# Altium schematic sheet [7] EEPROM_JTAG.SchDoc("[7] EEPROM_JTAG")
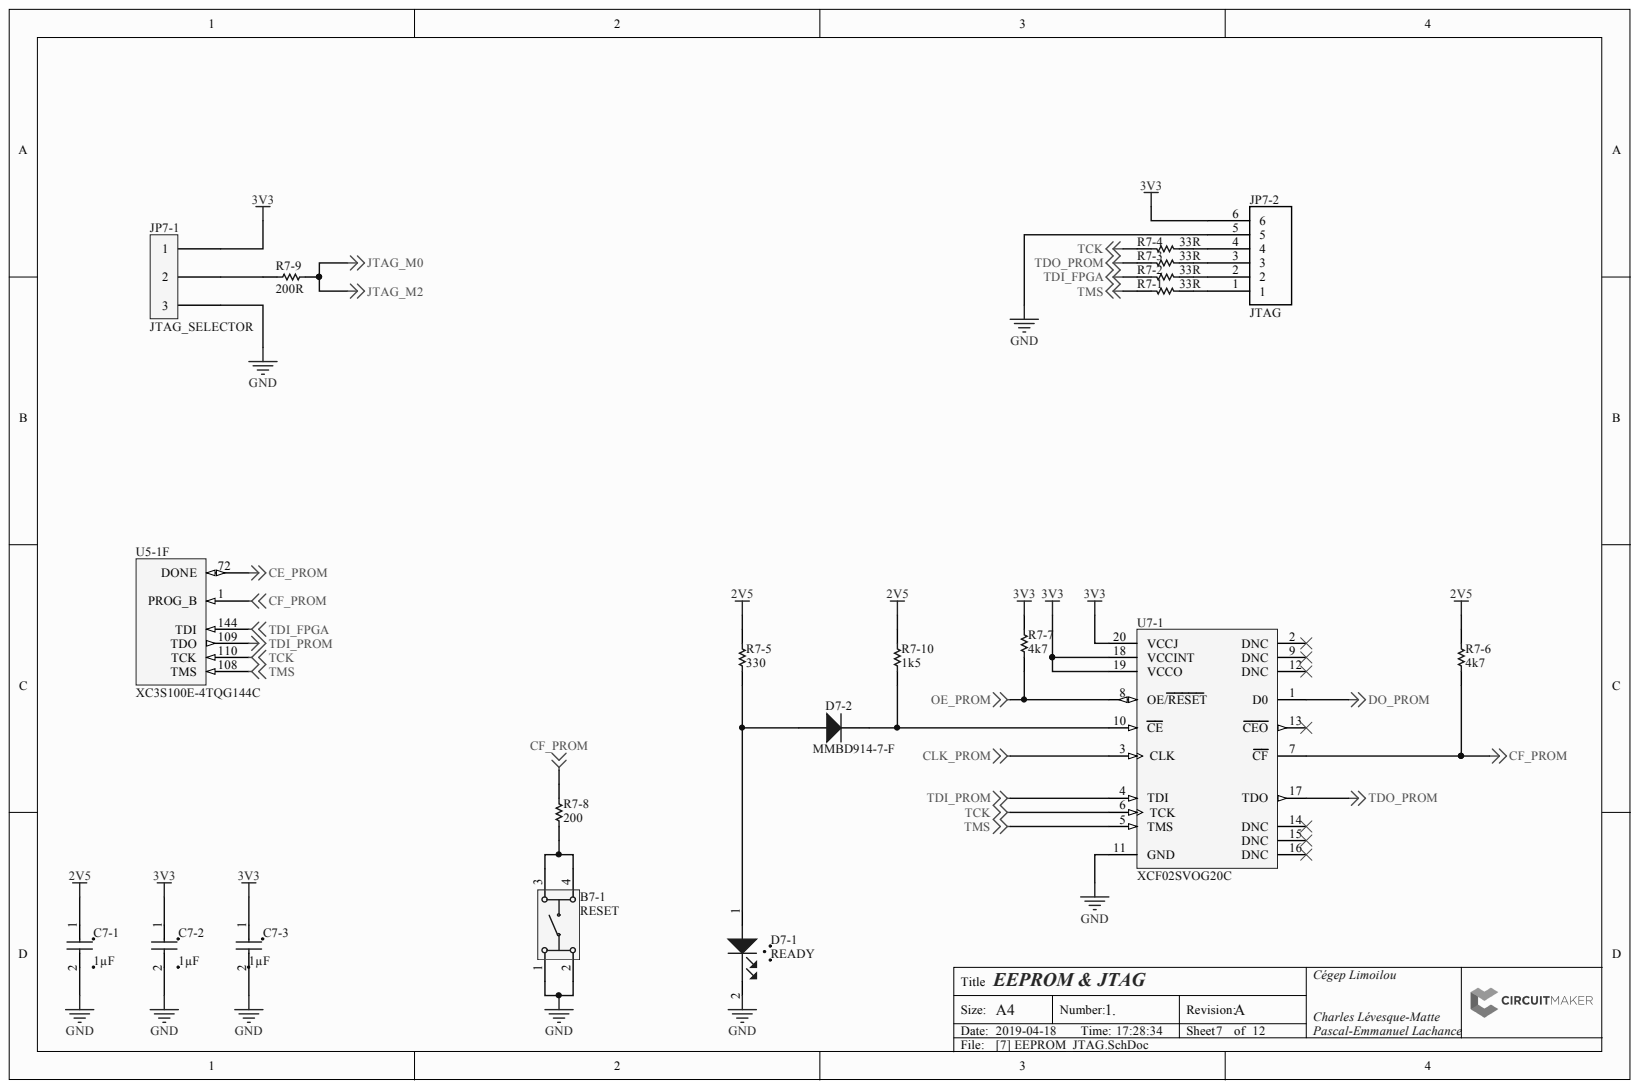
[diagram p0: [7] EEPROM_JTAG.SchDoc]

## Parts
B7-1  RESET  [PCB-gu3nyp8n3znpe43o61p2-1]
C7-1  1µF  [PCB-hxapk9n2qzqgg8bo7kac-1]
C7-2  1µF  [PCB-hxapk9n2qzqgg8bo7kac-1]
C7-3  1µF  [PCB-hxapk9n2qzqgg8bo7kac-1]
D7-1  READY  [PCB-loj9e2phlcze9wuynh4o-1]
D7-2  MMBD914-7-F  [PCB-c6nu3vtdsg4sq5t4bise-1]
JP7-1  JTAG_SELECTOR  [PCB-ugwstohemlnv9dppwl48-1]
JP7-2  JTAG  [PCB-3czfjzl4xee1cb5nxbdw-1]
R7-1  33R  [PCB-9ahxsq8gddjqcnfjmojj-1]
R7-2  33R  [PCB-9ahxsq8gddjqcnfjmojj-1]
R7-3  33R  [PCB-9ahxsq8gddjqcnfjmojj-1]
R7-4  33R  [PCB-9ahxsq8gddjqcnfjmojj-1]
R7-5  330  [RESC1508X06N]
R7-6  4k7  [PCB-g8ucq7vw1s2sdhfr4zem-1]
R7-7  4k7  [PCB-g8ucq7vw1s2sdhfr4zem-1]
R7-8  200  [PCB-u0msi5n9597hlhe0i4x3-1]
R7-9  200R  [PCB-u0msi5n9597hlhe0i4x3-1]
R7-10  1k5  [PCB-9ahxsq8gddjqcnfjmojj-1]
U5-1  XC3S100E-4TQG144C  [TQG144_L]  (part F)
U7-1  XCF02SVOG20C  [VOG20_M]

## Nets
2V5: C7-1.1 R7-5.2 R7-6.2 R7-10.2
3V3: C7-2.1 C7-3.1 JP7-1.1 JP7-2.6 R7-7.2 U7-1.18(VCCINT) U7-1.19(VCCO) U7-1.20(VCCJ)
CE_PROM: U5-1.72(DONE)
CF_PROM: R7-6.1 R7-8.2 U5-1.1(PROG_B) U7-1.7(CF)
CLK_PROM: U7-1.3(CLK)
DO_PROM: U7-1.1(D0)
GND: B7-1.1 B7-1.2 C7-1.2 C7-2.2 C7-3.2 D7-1.2 JP7-1.3 JP7-2.5 U7-1.11(GND)
JTAG_M0: R7-9.2
OE_PROM: R7-7.1 U7-1.8(OE/RESET)
TCK: R7-4.1 U5-1.110(TCK) U7-1.6(TCK)
TDI_FPGA: R7-2.1 U5-1.144(TDI)
TDI_PROM: U5-1.109(TDO) U7-1.4(TDI)
TDO_PROM: R7-3.1 U7-1.17(TDO)
TMS: R7-1.1 U5-1.108(TMS) U7-1.5(TMS)
NetB7-1_3: B7-1.3 B7-1.4 R7-8.1
NetD7-1_1: D7-1.1 D7-2.A R7-5.1
NetD7-2_K: D7-2.K R7-10.1 U7-1.10(CE)
NetJP7-1_2: JP7-1.2 R7-9.1
NetJP7-2_1: JP7-2.1 R7-1.2
NetJP7-2_2: JP7-2.2 R7-2.2
NetJP7-2_3: JP7-2.3 R7-3.2
NetJP7-2_4: JP7-2.4 R7-4.2

Not connected: U7-1 2(DNC) 9(DNC) 12(DNC) 13(CEO) 14(DNC) 15(DNC) 16(DNC)

## Library data of the parts
B7-1 = CMP-1012202-7: Switch Tactile N.O. SPST Round Button Gull Wing 0.05A 12VDC 100000Cycles 1.6N SMD Box; Manufacturer Part Number: 1301.9314; Contacts Type: SPST-NO; Component Kind: Standard; Library Name: FavoritesLibrary; Mounting Style: Surface Mount; OctopartID: 06e1cfb9c64ad926; Octopart URL: https://octopart.com/1301.9314-schurter-5432781; Operating Temperature: 70.0 °C (max); Packaging: Bulk; REACH SVHC Compliance: No SVHC; RoHS: Compliant
C7-1-C7-3 = CMP-f7023f64287128c5-2: Manufacturer Part Number: CL10A105KB8NNNC; Capacitance: 1.00 µF; Capacitance Tolerance: ±10%; Dielectric Characteristic: X5R; Lead-Free Status: Lead Free; Voltage Rating (DC): 50.0 V; Case/Package: 0603; Component Kind: Standard; Library Name: CommunityVault; Mounting Style: Surface Mount; OctopartID: f7023f64287128c5; Octopart URL: https://octopart.com/cl10a105kb8nnnc-samsung-23919125; Packaging: Tape & Reel (TR); RoHS: Compliant
D7-1 = CMP-2ca2e5a06a69f365-1: Manufacturer Part Number: LTST-C150CKT; Case/Package (SI): 3216; Color: Red; Forward Voltage: 1.80 V; Lead-Free Status: Lead Free; Lens Type: Non-Diffused; Luminous Intensity: 25.0 mcd; Viewing Angle: 130 °; Wavelength: 660 nm; Case/Package: 1206; Component Kind: Standard; Library Name: CommunityVault; Mounting Style: Surface Mount, Vertical; OctopartID: 2ca2e5a06a69f365; Octopart URL: http://octopart.com/ltst-c150ckt-lite-on-549805; Packaging: Tape & Reel (TR); RoHS: Compliant; Size-Height: 1.10 mm; Size-Length: 3.20 mm; Size-Width: 1.60 mm
D7-2 = CMP-c6b50fbaed7b1972-2: DIODE, ULTRAFAST RECOVERY, 200mA, 75V, SOT-23-3, FULL REEL; Capacitance: 2.00 pF; Output Current: 200 mA (max); Voltage Rating (DC): 75.0 V; Component Kind: Standard; Current Rating: 200 mA; Library Name: CommunityVault; Mounting Style: Surface Mount; OctopartID: c6b50fbaed7b1972; REACH SVHC Compliance: No SVHC
JP7-1 = CMP-e6852ab6724f3942-2: Connector, Header, 3Pos, 1Row, 2.54Mm; Manufacturer Part Number: PR20203VBNN; Gender: Male; Component Kind: Standard; Contact Plating: Gold; Library Name: CommunityVault; Mounting Style: Solder; OctopartID: e6852ab6724f3942; Octopart URL: https://octopart.com/pr20203vbnn-metz+connect-87667116; RoHS: Compliant
JP7-2 = CMP-cc1d077bc18a13da-10: Single Row 6 Position 2.54 mm Pitch Straight Unshrouded Male Pin Header; Number of Contacts: 6; Component Kind: Standard; Contact Plating: Tin; Gender: Male; Library Name: CommunityVault
R7-1-R7-4 = CMP-88ab408486b9576c-1: Res Thick Film 0603 33 Ohm 1% 0.1W(1/10W) ??100ppm/??C Molded SMD Paper T/R; Manufacturer Part Number: RMCF0603FT33R0; Power Rating: 100 mW; Resistance: 33.0 Ω; Case/Package: 0603; Component Kind: Standard; Library Name: CommunityVault; Mounting Style: Surface Mount; OctopartID: 88ab408486b9576c; Octopart URL: https://octopart.com/rmcf0603ft33r0-stackpole+electronics-19213172; Packaging: Tape & Reel (TR); Resistance Tolerance: ±1%; RoHS: Compliant
R7-5 = CMP-519899b75f918e5f-1: Res Thick Film 0603 330 Ohm 1% 0.1W(1/10W) ???}100ppm/??? Molded SMD Automotive Paper T/R; Manufacturer Part Number: RMCF0603FT330R; Lead-Free Status: Lead Free; Power Rating: 100 mW; Resistance: 330 Ω; Case/Package: 0603; Component Kind: Standard; Library Name: CommunityVault; Mounting Style: Surface Mount; OctopartID: 519899b75f918e5f; Packaging: Tape & Reel (TR); Resistance Tolerance: ±1%; RoHS: Compliant
R7-6 R7-7 = CMP-011ec9310fadded9-4: Res Thick Film 0603 4.7K Ohm 1% 0.1W(1/10W) ±100ppm/C Pad SMD Automotive T/R; Manufacturer Part Number: RMCF0603FT4K70; Lead-Free Status: Lead Free; Power Rating: 100 mW; Resistance: 4.70 kΩ; Case/Package: 0603; Component Kind: Standard; Library Name: CommunityVault; Mounting Style: Surface Mount; OctopartID: 011ec9310fadded9; Octopart URL: https://octopart.com/rmcf0603ft4k70-stackpole+electronics-19213269; Packaging: Tape & Reel (TR); Resistance Tolerance: ±1%; RoHS: Compliant
R7-8 R7-9 = CMP-f67e3e51f81629b9-1: Res Thick Film 0603 200 Ohm 1% 0.1W(1/10W) ±100ppm/C Pad SMD Automotive T/R; Manufacturer Part Number: RMCF0603FT200R; Lead-Free Status: Lead Free; Power Rating: 100 mW; Case/Package: 0603; Component Kind: Standard; Library Name: CommunityVault; Mounting Style: Surface Mount; Packaging: Tape & Reel (TR)
R7-10 = CMP-5114993b5eae8ed3-1: Res Thick Film 0603 1.5K Ohm 1% 0.1W(1/10W) ±100ppm/C Pad SMD Automotive T/R; Component Kind: Standard; Library Name: CommunityVault; Mounting Style: Surface Mount
U5-1 = CMP-971465-2: Spartan-3E 1.2V FPGA, 108 User I/Os, 144-Pin TQFP, Standard Performance, Commercial Grade, Pb-Free; Lead-Free Status: Lead Free; Number of Gates: 100000; Number of I/O Pins: 108; RAM Memory Size: 9216 B; Case/Package: TQFP; Component Kind: Standard; Library Name: CommunityVault; Manufacturer Part Number: XC3S100E-4TQG144C; Mounting Style: Surface Mount; Number of Pins: 144; OctopartID: 2992e09f0182f655; Octopart Url: https://octopart.com/xc3s100e-4tqg144c-xilinx-462198; Packaging: Tray; RoHS: Compliant; Supply Voltage (DC): 1.2 V
U7-1 = CMP-11781061-2: XCF00S Series, Platform Flash In-System Programmable Configuration 3.3V PROM, 20-Pin TSOP, 2-Megabit, Commercial Grade, Pb-Free; Clock Speed: 50000000.0 Hz; Lead-Free Status: Lead Free; Memory Size: 250000 B; Case/Package: TSSOP; Component Kind: Standard; Library Name: CommunityVault; Manufacturer Part Number: XCF02SVOG20C; Mounting Style: Surface Mount; Number of Pins: 20; OctopartID: 6d42a44ec671b285; Octopart Url: https://octopart.com/xcf02svog20c-xilinx-429653; Packaging: Tube; REACH SVHC Compliance: No SVHC; RoHS: Compliant; Supply Voltage (DC): 3.3 V
